# Altium schematic sheet KillSwitch.SchDoc(KillSwitch)
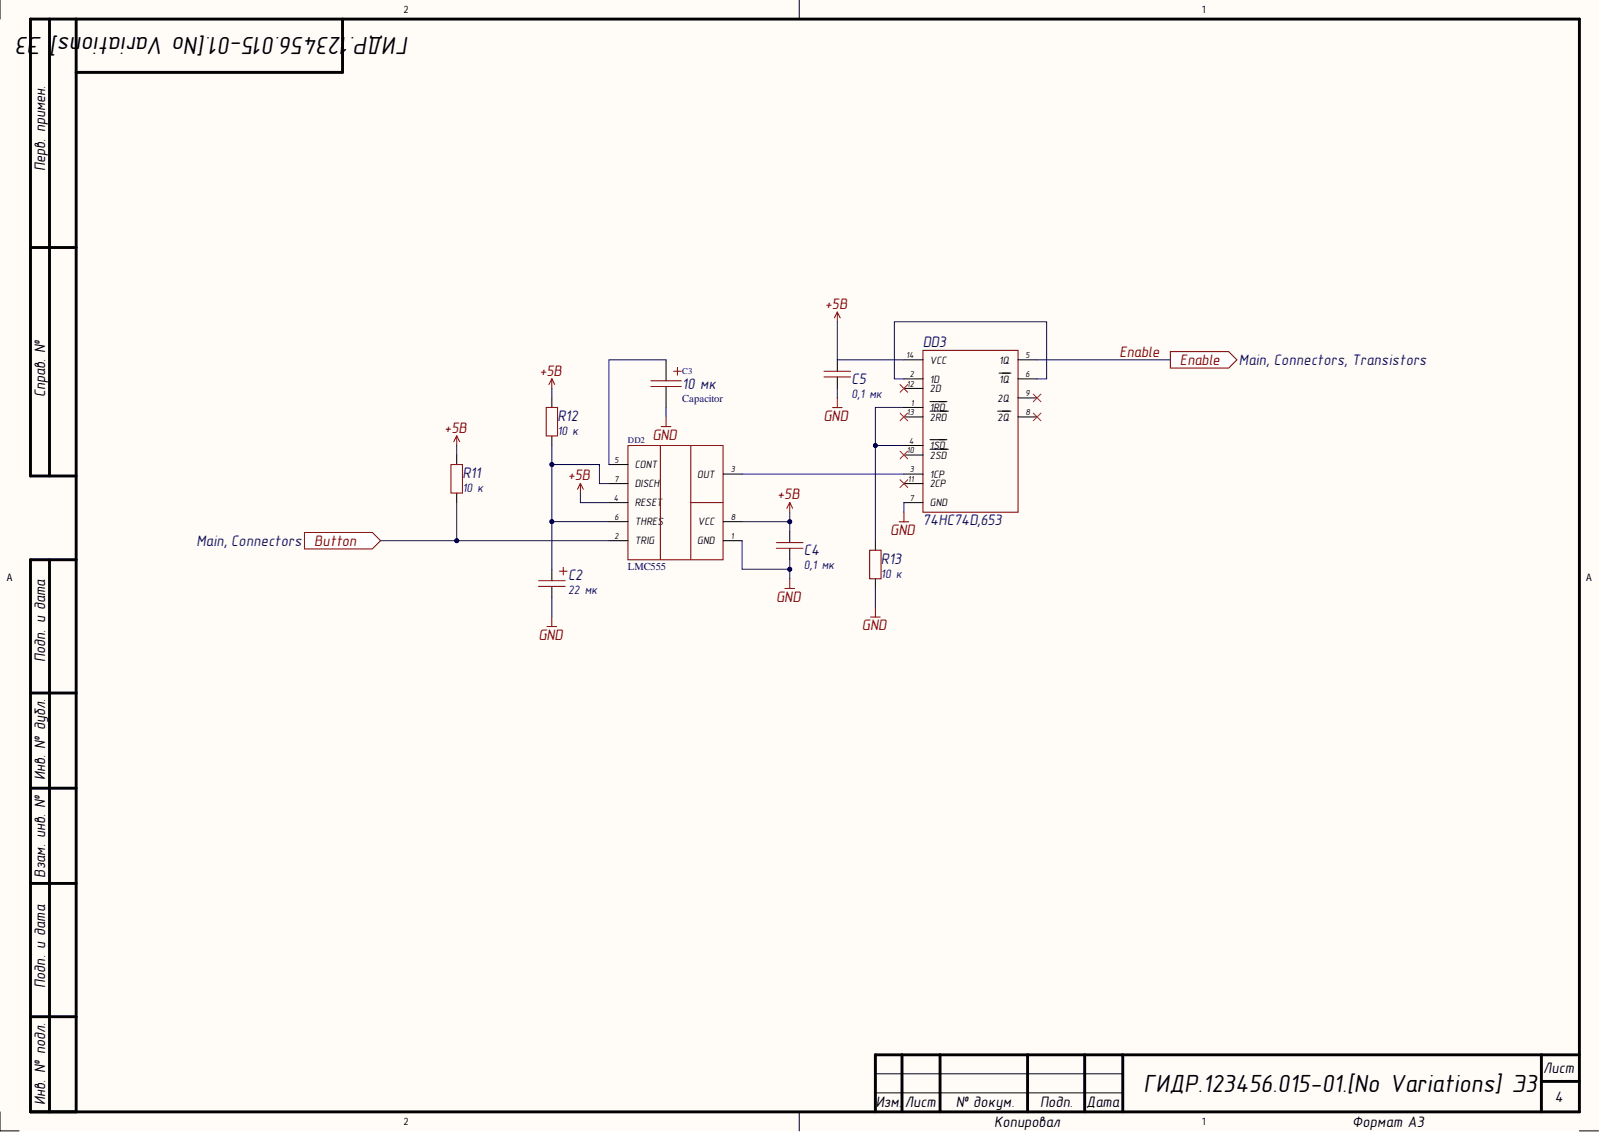
[diagram p0: KillSwitch.SchDoc]

## Parts
C2  Capacitor  value 22 мк  [TC_A]
C3  Capacitor  value 10 мк  [TC_A]
C4  Capacitor  value 0,1 мк  Tolerance: 0,1  [0603C]
C5  Capacitor  value 0,1 мк  Tolerance: 0,1  [0603C]
DD2  LMC555  [SOIC-8]
DD3  74HC74D,653  [SOIC-14]
R11  value 10 к  [0603R]  (hidden comment: Resistor)
R12  value 10 к  [0603R]  (hidden comment: Resistor)
R13  value 10 к  [0603R]  (hidden comment: Resistor)

## Ports
Button, Enable

## Nets
+5В: C4.2 C5.1 DD2.4(RESET) DD2.8(VCC) DD3.14(VCC) R11.2 R12.2
GND: C2.2 C3.2 C4.1 C5.2 DD2.1(GND) DD3.7(GND) R13.1
Button: DD2.2(TRIG) R11.1 ; port Button
Enable: DD3.5(1Q) ; port Enable
NetC2_1: C2.1 DD2.6(THRES) DD2.7(DISCH) R12.1
NetC3_1: C3.1 DD2.5(CONT)
NetDD2_3: DD2.3(OUT) DD3.3(1CP)
NetDD3_1: DD3.1(1RD) DD3.4(1SD) R13.2
NetDD3_2: DD3.2(1D) DD3.6(1Q)
NetDD3_8: DD3.8(2Q)
NetDD3_9: DD3.9(2Q)
NetDD3_10: DD3.10(2SD)
NetDD3_11: DD3.11(2CP)
NetDD3_12: DD3.12(2D)
NetDD3_13: DD3.13(2RD)

## Library data of the parts
C2 = Capacitor_22u_10_50V_TC_A: Танталовый конденсатор; Capacity (uF): 22; Database Table Name: Capacitors_electrolytic$; Tolerance: 0,1; Type: Tantalum; Voltage (V): 50; Component Kind: Standard; Library Name: Hydrolib.DbLib; Part Number: Capacitor_22u_10_50V_TC_A
C3 = Capacitor_10u_10_50V_TC_A: Танталовый конденсатор; Capacity (uF): 10; Database Table Name: Capacitors_electrolytic$; Tolerance: 0,1; Type: Tantalum; Voltage (V): 50; Component Kind: Standard; Library Name: Hydrolib.DbLib; Part Number: Capacitor_10u_10_50V_TC_A
C4 C5 = Capacitor_0,1u_10_50V_X7R_0603C: Конденсатор; Capacity (nF): 100; Database Table Name: Capacitors_ceramic$; Dielectric: X7R; Library Path2: Schematic\Capacitor.SchLib; Voltage (V): 50; Component Kind: Standard; Library Name: Hydrolib.DbLib; Part Number: Capacitor_0,1u_10_50V_X7R_0603C
DD2 = LMC555: Таймер интегральный прецизионный КМОП; Database Table Name: Chips$; Component Kind: Standard; Library Name: Hydrolib.DbLib; Part Number: LMC555
DD3 = 74HC74D,653: Два триггера D-типа; Database Table Name: Chips$; MF: Nexperia USA; Check_prices: https://www.snapeda.com/parts/74HC74D,653/Nexperia/view-part/?ref=eda; Component Kind: Standard; Library Name: Hydrolib.DbLib; MP: 74HC74D,653; Package: SO14-14 Nexperia USA Inc.; Part Number: 74HC74D,653; SnapEDA_Link: https://www.snapeda.com/parts/74HC74D,653/Nexperia/view-part/?ref=snap
R11-R13 = Resistor_10k_1_0603: Резистор номиналом 10 кОм и точностью 1%; Database Table Name: Resistors$; Component Kind: Standard; Library Name: Hydrolib.DbLib; Part Number: Resistor_10k_1_0603; Tolerance: 1%
